# Altium schematic sheet SC20100S-Misc.SchDoc(SC20100S-Misc)
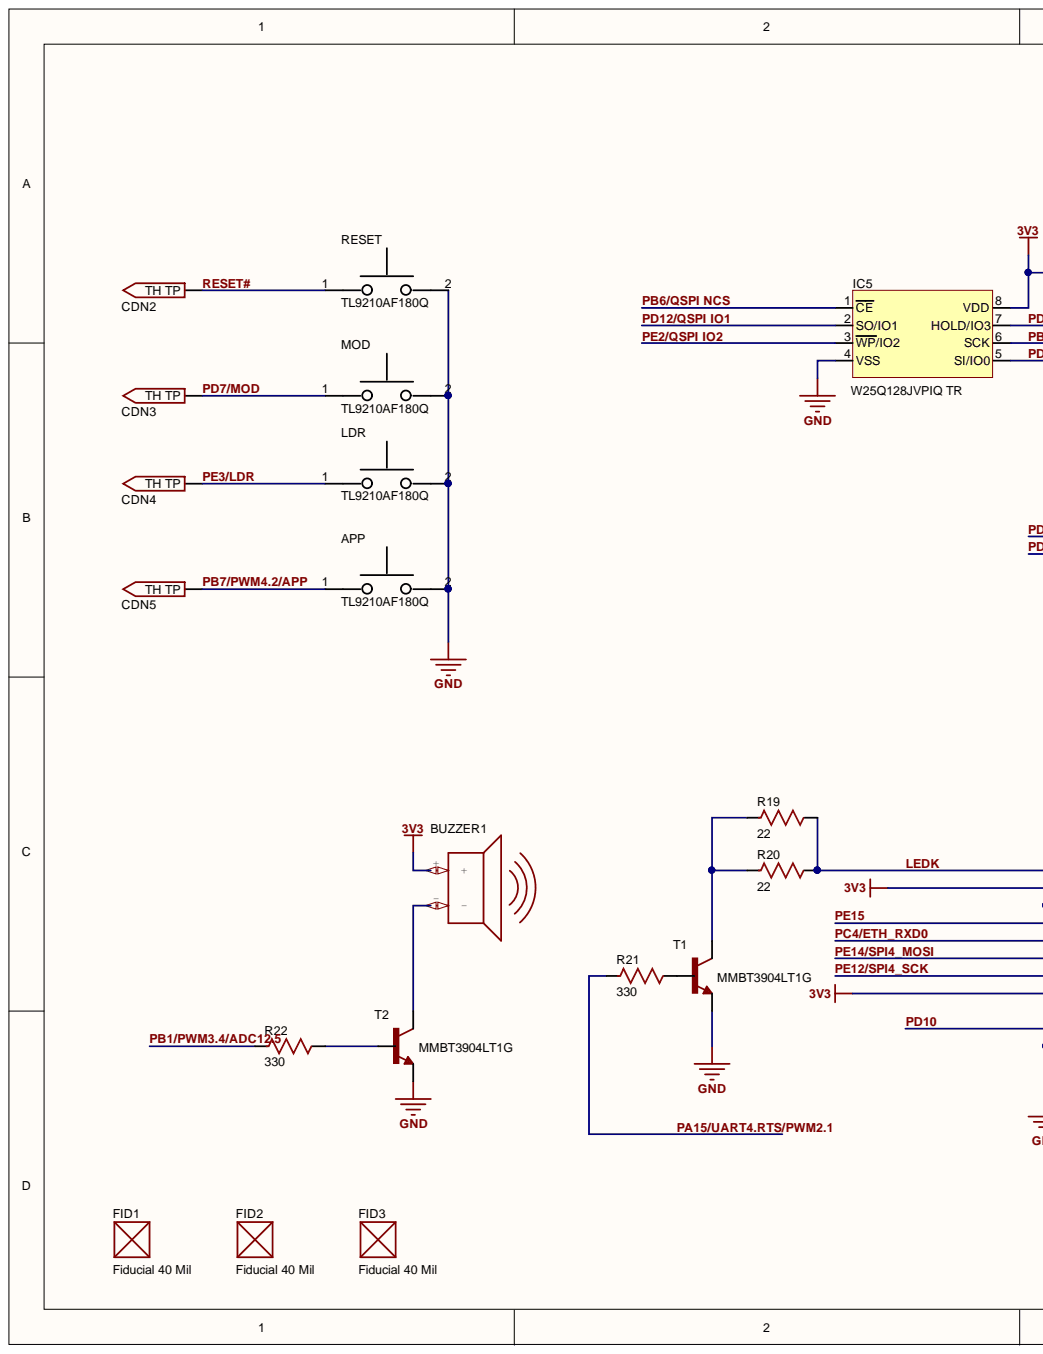
[diagram p0: SC20100S-Misc.SchDoc, left]
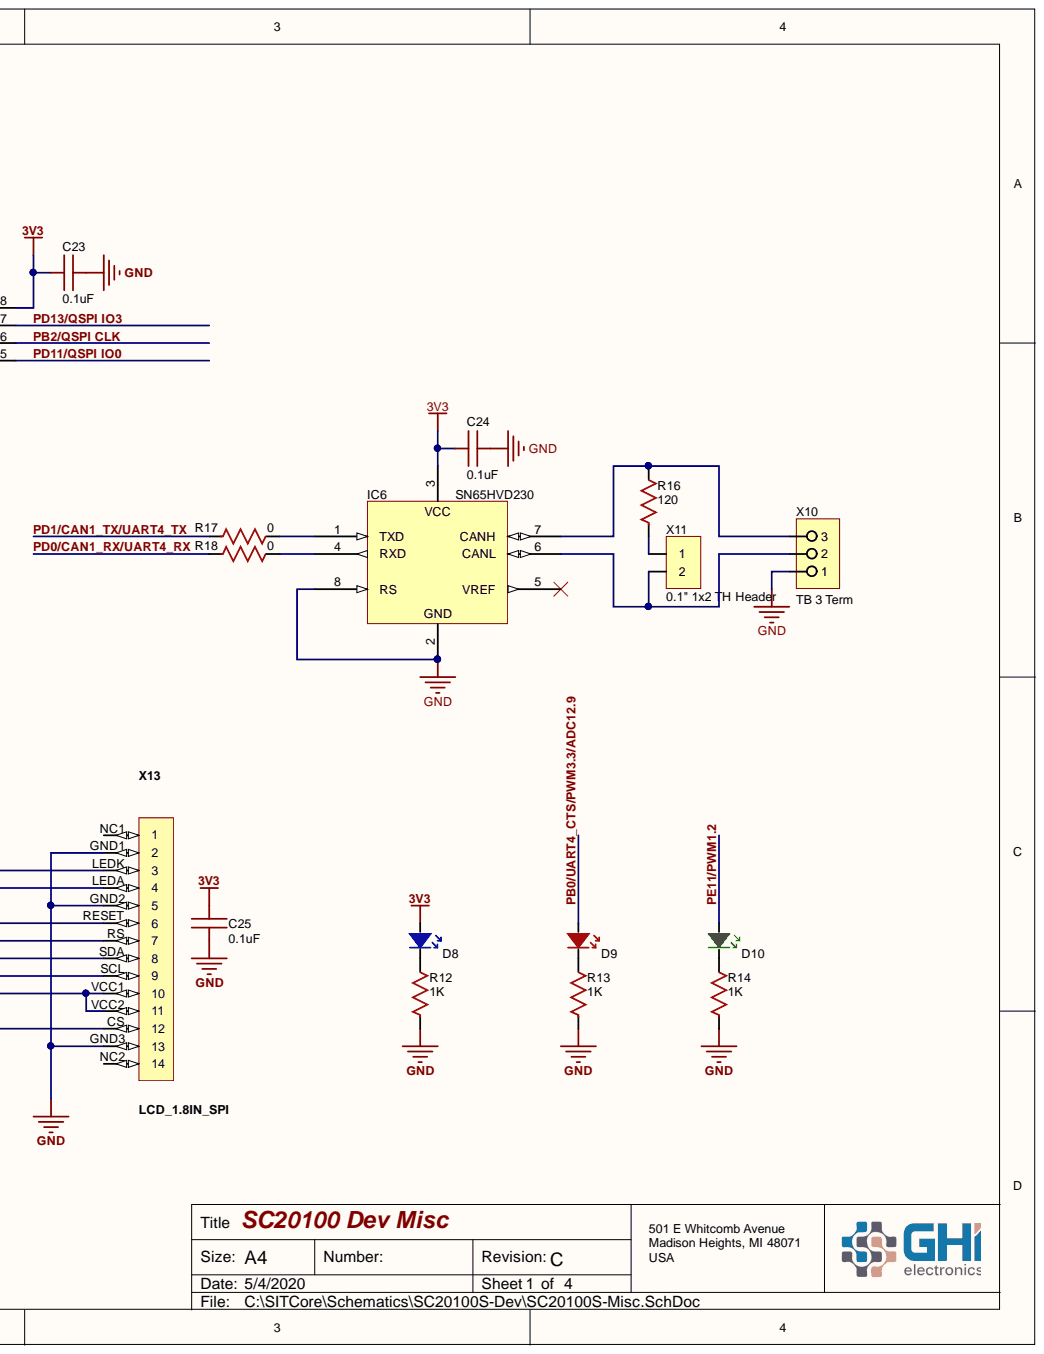
[diagram p1: SC20100S-Misc.SchDoc, right]

## Parts
APP  value TL9210AF180Q  [Button - TL9210]  (hidden comment: Tactile Switch)
BUZZER1  Buzzer - Small  [KLJ-SMD-BUZZER]  (value not drawn)
C23  value 0.1uF  [C0603 (1608 Metric)]  (hidden comment: 0603 Cap 0.1uF)
C24  value 0.1uF  [C0603 (1608 Metric)]  (hidden comment: 0603 Cap 0.1uF)
C25  value 0.1uF  [C0603 (1608 Metric)]  (hidden comment: 0603 Cap 0.1uF)
CDN2  TH Testpad 32mil (32mil ar)  [32mil TH]  (value not drawn)  (no BOM)
CDN3  TH Testpad 32mil (32mil ar)  [32mil TH]  (value not drawn)  (no BOM)
CDN4  TH Testpad 32mil (32mil ar)  [32mil TH]  (value not drawn)  (no BOM)
CDN5  TH Testpad 32mil (32mil ar)  [32mil TH]  (value not drawn)  (no BOM)
D8  0603 LED Blue  [0603 LED Blue]  (value not drawn)
D9  0603 LED Red  [0603 LED Red]  (value not drawn)
D10  0603 LED Green  [0603 LED Green]  (value not drawn)
FID1  Fiducial 40 Mil  [Fiducial 40 Mil]  (no BOM)
FID2  Fiducial 40 Mil  [Fiducial 40 Mil]  (no BOM)
FID3  Fiducial 40 Mil  [Fiducial 40 Mil]  (no BOM)
IC5  value W25Q128JVPIQ TR  [WSON 8 6x5mm]  (hidden comment: FLASH - NOR Memory IC 128Mb)
IC6  SN65HVD230  [8-Pin SOIC]
LDR  value TL9210AF180Q  [Button - TL9210]  (hidden comment: Tactile Switch)
MOD  value TL9210AF180Q  [Button - TL9210]  (hidden comment: Tactile Switch)
R12  value 1K  [R0603 (1608 Metric)]  (hidden comment: 0603 1K)
R13  value 1K  [R0603 (1608 Metric)]  (hidden comment: 0603 1K)
R14  value 1K  [R0603 (1608 Metric)]  (hidden comment: 0603 1K)
R16  value 120  [R0603 (1608 Metric)]  (hidden comment: 0603 120)
R17  value 0  [R0603]  (hidden comment: 0603 Res 0)
R18  value 0  [R0603]  (hidden comment: 0603 Res 0)
R19  value 22  [R0603 (1608 Metric)]  (hidden comment: 0603 22)
R20  value 22  [R0603 (1608 Metric)]  (hidden comment: 0603 22)
R21  value 330  [R0603 (1608 Metric)]  (hidden comment: 0603 330)
R22  value 330  [R0603 (1608 Metric)]  (hidden comment: 0603 330)
RESET  value TL9210AF180Q  [Button - TL9210]  (hidden comment: Tactile Switch)
T1  3904  value MMBT3904LT1G  [SOT23]
T2  3904  value MMBT3904LT1G  [SOT23]
X10  TB 3 Term  [TB 5mm Pitch 3 Term]
X11  0.1" 1x2 TH Header  [TH 0.1" 1x2 (35mil diameter)]
X13  LCD_1.8IN_SPI  [LCD_1.8IN]

## Nets
3V3: BUZZER1.+ C23.1 C24.1 C25.2 D8.2 IC5.8(VDD) IC6.3 X13.LEDA X13.VCC1 X13.VCC2
GND: APP.2 C23.2 C24.2 C25.1 IC5.4(VSS) IC6.2 IC6.8(RS) LDR.2 MOD.2 R12.1 R13.1 R14.1 RESET.2 T1.2 T2.2 X10.1 X13.GND1 X13.GND2 X13.GND3
LEDK: R19.2 R20.2 X13.LEDK
PA15/UART4.RTS/PWM2.1: R21.1
PB0/UART4_CTS/PWM3.3/ADC12.9: D9.2
PB1/PWM3.4/ADC12.5: R22.1
PB2/QSPI CLK: IC5.6(SCK)
PB6/QSPI NCS: IC5.1(CE)
PB7/PWM4.2/APP: APP.1 CDN5.1
PC4/ETH_RXD0: X13.RS
PD0/CAN1_RX/UART4_RX: R18.1
PD1/CAN1_TX/UART4_TX: R17.1
PD7/MOD: CDN3.1 MOD.1
PD10: X13.CS
PD11/QSPI IO0: IC5.5(SI/IO0)
PD12/QSPI IO1: IC5.2(SO/IO1)
PD13/QSPI IO3: IC5.7(HOLD/IO3)
PE2/QSPI IO2: IC5.3(WP/IO2)
PE3/LDR: CDN4.1 LDR.1
PE11/PWM1.2: D10.2
PE12/SPI4_SCK: X13.SCL
PE14/SPI4_MOSI: X13.SDA
PE15: X13.RESET
RESET#: CDN2.1 RESET.1
NetBUZZER1_-: BUZZER1.- T2.3
NetD8_1: D8.1 R12.2
NetD9_1: D9.1 R13.2
NetD10_1: D10.1 R14.2
NetIC6_1: IC6.1(TXD) R17.2
NetIC6_4: IC6.4(RXD) R18.2
NetIC6_6: IC6.6(CANL) X10.2 X11.2
NetIC6_7: IC6.7(CANH) R16.2 X10.3
NetR16_1: R16.1 X11.1
NetR19_1: R19.1 R20.1 T1.3
NetR21_2: R21.2 T1.1
NetR22_2: R22.2 T2.1

Not connected: IC6 5(VREF); X13 NC1 NC2

## Library data of the parts
APP LDR MOD RESET = Tactile Switch: SPST 50mA @ 12VDC Top Actuated Plunger for Cap SMD,6x6mm Tactile Switches RoHS; Manufacturer: E-Switch; Package: SMD,6x6mm; Mfr.Part #: TL9210AF180Q; LCSC Part #: C273538; Component Kind: Standard; Library Name: GHI.SchLib
BUZZER1 = KLJ-8530-5027: Piezo Speaker from CHANGZHOU KELIKING ELECTRONICS CO., LTD; Value: KLJ-8530-5027; PartNo: KLJ-8530-5027; Component Kind: Standard; Library Name: GHI.SchLib
C23-C25 = 0603 Cap 0.1uF: 0.1uF ±5% 50V X7R 0603 Multilayer Ceramic Capacitors MLCC - SMD/SMT RoHS; Mfr.Part #: CL10B104JB8NNNC; Voltage: 50V; Tolerance: ±5%; Package: 0603; LCSC Part #: C24452; Digikey: 1276-1033-1-ND; Component Kind: Standard; Category: Ceramic; Capacitance: 0.1uF; Library Name: GHI-Passives.SchLib
CDN2-CDN5 = TH Testpad 32mil (32mil ar): TH Testpad 32mil (32mil annular ring); Library Name: GHI.SchLib
D8 = 0603 LED Blue: Blue 468nm 0603 Light Emitting Diodes (LED) RoHS; Mfr.Part #: LTST-C193TBKT-5A; Manufacturer: Lite-On; Value: 0603 LED Blue; Package: 0603; LCSC Part #: C125103; Component Kind: Standard; Color: Blue; Library Name: GHI-Opto.SchLib
D9 = 0603 LED Red: Red 631nm 0603 Light Emitting Diodes (LED) RoHS; Mfr.Part #: LTST-C193KRKT-5A; Manufacturer: Lite-On; Value: 0603 LED Red; Package: 0603; LCSC Part #: C157741; Component Kind: Standard; Color: Red; Library Name: GHI-Opto.SchLib
D10 = 0603 LED Green: Green 567.5~576.5nm 0603 Light Emitting Diodes (LED) RoHS; Mfr.Part #: LTST-C193KGKT-5A; Manufacturer: Lite-On; Value: 0603 LED Green; Package: 0603; LCSC Part #: C125102; Component Kind: Standard; Color: Green; Library Name: GHI-Opto.SchLib
IC5 = W25Q128JVPIQ: Manufacturer: Winbond Elec; Package: 8-WDFN Exposed Pad; Mfr.Part #: W25Q128JVPIQ TR; LCSC Part #: C190862; Digikey Part No: W25Q128JVPIQCT-ND; Description: FLASH - NOR Memory IC 128Mb; Component Kind: Standard; Library Name: GHI - Integrated Circuits.SchLib
IC6 = SN65HVD23x: TI 3.3-V CAN Bus Transceivers (SN65HVD23x ); Value: SN65HVD230; PartNo: SN65HVD230; Component Kind: Standard; Library Name: GHI.SchLib
R12-R14 = 0603 Res 1K: 1K ±5% 1/10W ±100ppm/℃ 0603 Chip Resistor - Surface Mount RoHS; Mfr.Part #: WR06X102 JTL; Manufacturer: Walsin Tech Corp; Voltage Rating: *V; Resistance Tolerance: ±5%; Resistance: 1K; Power Rating: 1/10W; Package: 0603; LCSC Part #: C384295; Component Kind: Standard; Library Name: GHI-Passives.SchLib
R16 = 0603 Res 120: 120 ±1% 1/10W ±100ppm/℃ 0603 Chip Resistor - Surface Mount RoHS; Mfr.Part #: RC0603FR-07120RL; Manufacturer: YAGEO; Voltage Rating: *V; Resistance Tolerance: ±1%; Resistance: 120; Power Rating: 1/10W; Package: 0603; LCSC Part #: C114640; Component Kind: Standard; Library Name: GHI-Passives.SchLib
R17 R18 = 0603 Res 0: ±5% 1/10W 0603 Chip Resistor - Surface Mount RoHS; Mfr.Part #: RC0603JR-070RL; Manufacturer: YAGEO; Voltage Rating: *V; Resistance Tolerance: ±5%; Resistance: 0; Power Rating: 1/10W; Package: 0603; LCSC Part #: C95177; Component Kind: Standard; Library Name: GHI-Passives.SchLib
R19 R20 = 0603 Res 22: 22 ±1% 1/10W ±100ppm/℃ 0603 Chip Resistor - Surface Mount RoHS; Mfr.Part #: RC0603FR-0722RL; Manufacturer: YAGEO; Voltage Rating: *V; Resistance Tolerance: ±1%; Resistance: 22; Power Rating: 1/10W; Package: 0603; LCSC Part #: C107701; Component Kind: Standard; Library Name: GHI-Passives.SchLib
R21 R22 = 0603 Res 330: 330 ±5% 1/10W ±100ppm/℃ 0603 Chip Resistor - Surface Mount RoHS; Mfr.Part #: WR06X331 JTL; Manufacturer: Walsin Tech Corp; Voltage Rating: *V; Resistance Tolerance: ±5%; Resistance: 330; Power Rating: 1/10W; Package: 0603; LCSC Part #: C384242; Component Kind: Standard; Library Name: GHI-Passives.SchLib
T1 T2 = MMBT3904LT1G: NPN 200mA 40V 300mW SOT-23(SOT-23-3) Transistors (NPN/PNP) RoHS; Manufacturer: ON Semicon; Package: SOT-23(SOT-23-3); Mfr.Part #: MMBT3904LT1G; LCSC Part #: C81464; Component Kind: Standard; Library Name: GHI-Semiconductors.SchLib
X10 = TB 3 Term 5mm Pitch: Terminal block 3 terminals 5mm pitch; Component Kind: Standard; Library Name: GHI Sch.SchLib
X11 = 1x2 Pin 0.1" TH: Description: 1x2 Pin 0.1" TH; Component Kind: Standard; Library Name: GHI Headers.SchLib
X13 = LCD_1.8IN_SPI: SymbolName_1: 14_PINS; GateName_1: G$1; DeviceSetName: LCD_1.8IN_SPI; Component Kind: Standard; LibraryName: GHI; Library Name: GHI Sch.SchLib
FID1-FID3 = Fiducial 40 Mil: Library Name: GHI.SchLib
